# Altium schematic sheet Power and Ignition and Primary Processor.SchDoc
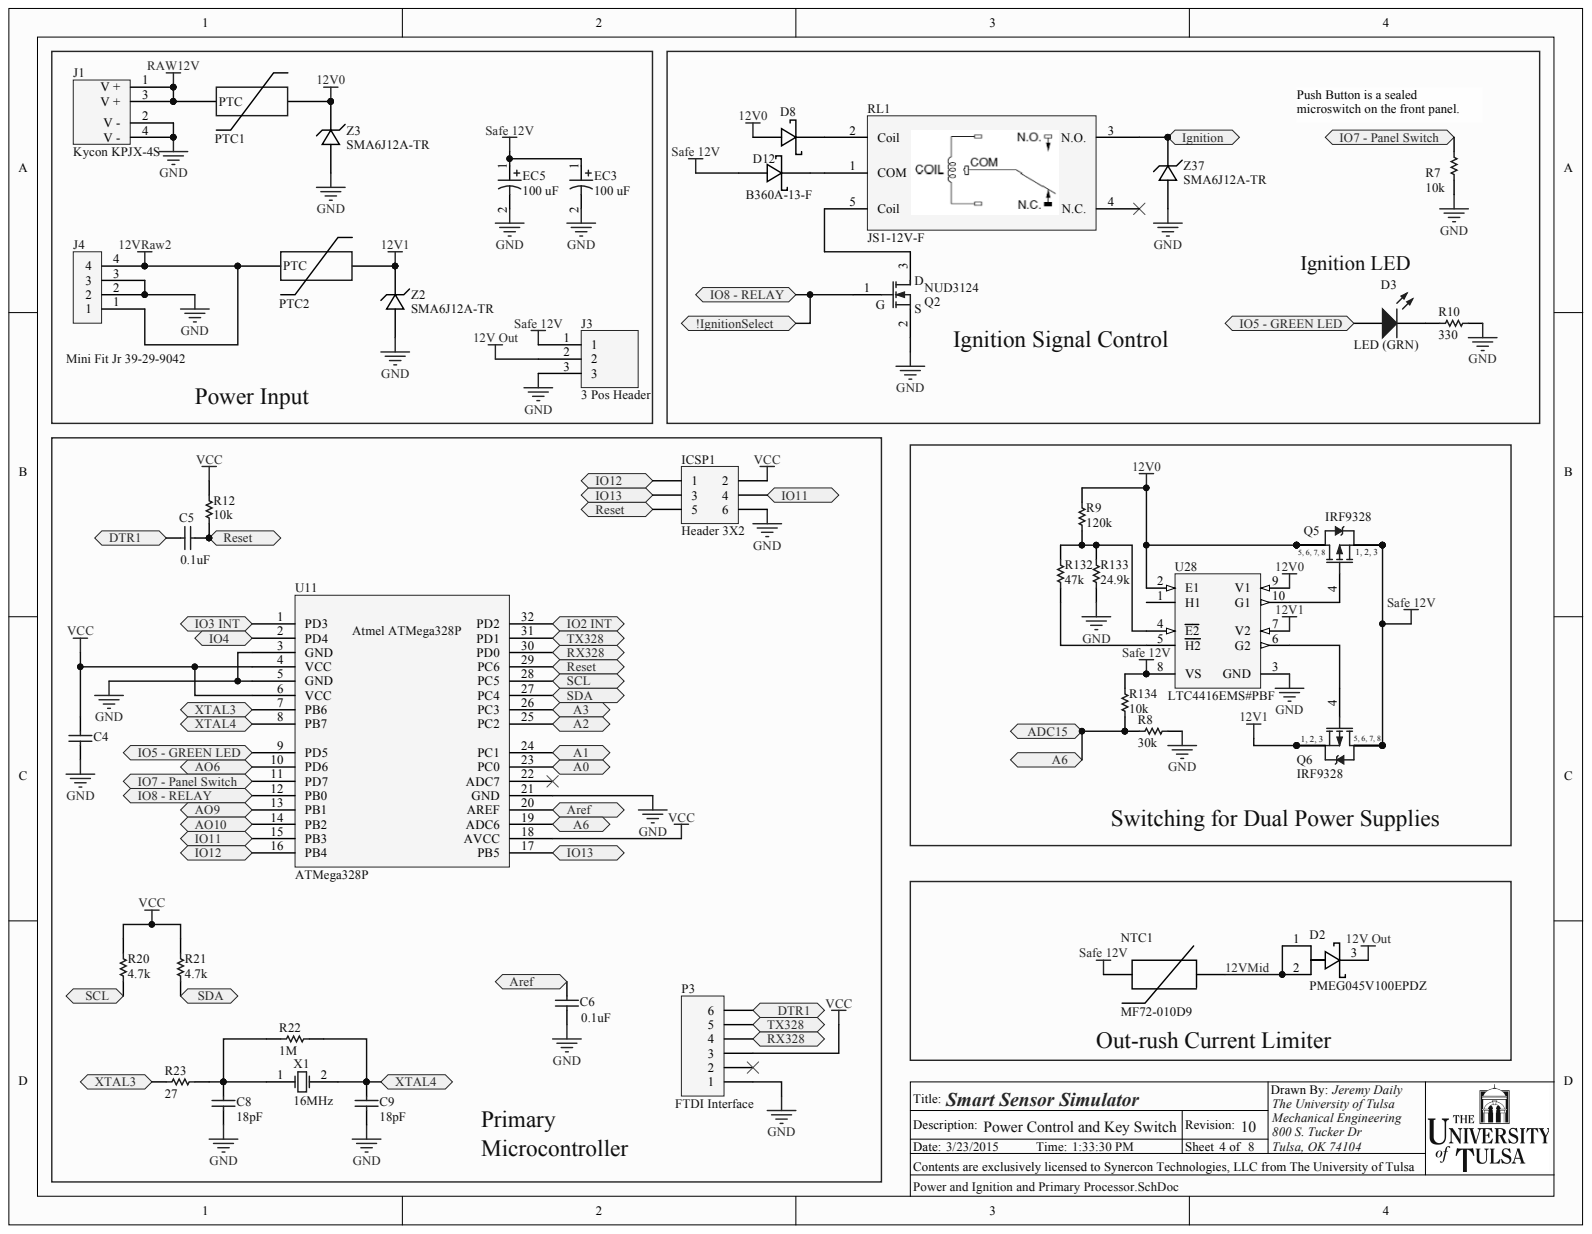
[diagram p0: Power and Ignition and Primary Processor.SchDoc]

## Parts
C4  0.1uF  [0603]  (value not drawn)
C5  0.1uF  [0603]
C6  0.1uF  [0603]
C8  18pF  [0603]
C9  18pF  [0603]
D2  PMEG045V100EPDZ  [SOT1289]
D3  LED (GRN)  [1206 Polarized]
D8  B360A-13-F  [SMA with A and K]
D12  B360A-13-F  [SMA with A and K]
EC3  100 uF  [Elec. Cap - D]
EC5  100 uF  [Elec. Cap - D]
ICSP1  Header 3X2  [ICSP Header (2x3)]
J1  Kycon KPJX-4S  Manufacturer: Kycon  [KPJX-4S-S]
J3  3 Pos Header  [3 POS MINI-FIT JR]
J4  Mini Fit Jr 39-29-9042  [4 POS Vertical MINI-FIT JR]
NTC1  MF72-010D9  [NTC 7.5mm]
P3  FTDI Interface  [FTDI Header]
PTC1  TE PTC  [PTC]  (value not drawn)
PTC2  TE PTC  [PTC]  (value not drawn)
Q2  NUD3124  [SOT-23-3]
Q5  IRF9328  [SO-8_L]
Q6  IRF9328  [SO-8_L]
R7  10k  [0805]
R8  30k  [0805]
R9  120k  [0805]
R10  330  [0603]
R12  10k  [0805]
R20  4.7k  [0805]
R21  4.7k  [0805]
R22  1M  [0603]
R23  27  [0805]
R132  47k  [0603]
R133  24.9k  [0805]
R134  10k  [0805]
RL1  JS1-12V-F  [Relay-JS]
U11  ATMega328P  Manufacturer: Atmel  [32MA_M]
U28  LTC4416EMS#PBF  [LT-MS-10_M]
X1  16MHz  [XTAL 2 pad]
Z2  SMA6J12A-TR  [SMA with A and K]
Z3  SMA6J12A-TR  [SMA with A and K]
Z37  SMA6J12A-TR  [SMA with A and K]

## Ports
!IGNITIONSELECT, A0, A1, A2, A3, A6, ADC15, AO6, AO9, AO10, AREF, DTR1, IGNITION, IO2 INT, IO3 INT, IO4, IO5 - GREEN LED, IO7 - PANEL SWITCH, IO8 - RELAY, IO11, IO12, IO13, RESET, RX328, SCL, SDA, TX328, XTAL3, XTAL4

## Nets
12V0: D8.1 PTC1.2 Q5.5 Q5.6 Q5.7 Q5.8 R9.1 U28.2(E1) U28.9(V1) Z3.2
12V1: PTC2.2 Q6.1 Q6.2 Q6.3 U28.7(V2) Z2.2
12V OUT: D2.3 J3.2
12VRAW2: J4.1 J4.4 PTC2.1
GND: C4.1 C6.2 C8.1 C9.1 EC3.2 EC5.2 ICSP1.6 J1.2(V_-) J1.4(V_-) J3.3 J4.2 J4.3 P3.1 Q2.2 R7.2 R8.2 R10.2 R133.2 U11.3(GND) U11.5(GND) U11.21(GND) U28.3(GND) Z2.1 Z3.1 Z37.1
RAW12V: J1.1(V_+) J1.3(V_+) PTC1.1
SAFE 12V: D12.1 EC3.1 EC5.1 J3.1 NTC1.1 Q5.1 Q5.2 Q5.3 Q6.5 Q6.6 Q6.7 Q6.8 R134.1 U28.8(VS)
VCC: C4.2 ICSP1.2 P3.3 R12.1 R20.2 R21.2 U11.4(VCC) U11.6(VCC) U11.18(AVCC)
12VMID: D2.1 D2.2 NTC1.2
A0: U11.23(PC0) ; port A0
A1: U11.24(PC1) ; port A1
A2: U11.25(PC2) ; port A2
A3: U11.26(PC3) ; port A3
A6: R8.1 R134.2 U11.19(ADC6) ; port A6, port ADC15
AO6: U11.10(PD6) ; port AO6
AO9: U11.13(PB1) ; port AO9
AO10: U11.14(PB2) ; port AO10
DTR1: C5.2 P3.6 ; port DTR1
IO2 INT: U11.32(PD2) ; port IO2 INT
IO3 INT: U11.1(PD3) ; port IO3 INT
IO4: U11.2(PD4) ; port IO4
IO5 - GREEN LED: D3.1 U11.9(PD5) ; port IO5 - GREEN LED
IO8 - RELAY: Q2.1 U11.12(PB0) ; port IO8 - RELAY
IO11: ICSP1.4 U11.15(PB3) ; port IO11
IO12: ICSP1.1 U11.16(PB4) ; port IO12
IO13: ICSP1.3 U11.17(PB5) ; port IO13
RX328: P3.4 U11.30(PD0) ; port RX328
SCL: R20.1 U11.28(PC5) ; port SCL
SDA: R21.1 U11.27(PC4) ; port SDA
TX328: P3.5 U11.31(PD1) ; port TX328
XTAL3: R23.2 U11.7(PB6) ; port XTAL3
XTAL4: C9.2 R22.1 U11.8(PB7) X1.2 ; port XTAL4
NetC5_1: C5.1 ICSP1.5 R12.2 U11.29(PC6) ; port RESET
NetC6_1: C6.1 U11.20(AREF) ; port AREF
NetC8_2: C8.2 R22.2 R23.1 X1.1
NetD3_2: D3.2 R10.1
NetD8_2: D8.2 RL1.2(Coil)
NetD12_2: D12.2 RL1.1(COM)
NetQ2_3: Q2.3 RL1.5(Coil)
NetQ5_4: Q5.4 U28.10(G1)
NetQ6_4: Q6.4 U28.6(G2)
NetR7_1: R7.1 U11.11(PD7) ; port IO7 - PANEL SWITCH
NetR9_2: R9.2 R132.1 R133.1 U28.4(E2)
NetR132_2: R132.2 U28.5(H2)
NetRL1_3: RL1.3(N.O.) Z37.2 ; port IGNITION

Not connected: P3 2; RL1 4(N.C.); U11 22(ADC7); U28 1(H1)

## Library data of the parts
C4-C6 = Cap: CAP CER 0.1UF 50V 10% X7R 0603; Manufacturer: Murata Electronics North America; PartNumber: GRM188R71H104KA93D; Component Kind: Standard; Library Name: SSS Schematic Library.SchLib; Signal Integrity: Cap; Simulation: CAP; Supplier 1: Digi-Key; Supplier Part Number 1: 490-1519-1-ND
C8 C9 = Cap: CAP CER 18PF 50V 5% NP0 0603; Manufacturer: Kemet; PartNumber: C0603C180J5GACTU; Component Kind: Standard; Library Name: SSS Schematic Library.SchLib; Signal Integrity: Cap; Simulation: CAP; Supplier 1: Digi-Key; Supplier Part Number 1: 399-1052-1-ND
D2 = Schottky Diode 3pin: DIODE SCHOTTKY 45V 10A CFP15; Manufacturer: NXP Semiconductors; Component Kind: Standard; Library Name: SSS Schematic Library.SchLib; PartNumber: PMEG045V100EPDZ; Supplier 1: Digi-Key; Supplier Part Number 1: 568-11697-1-ND
D3 = SMT LED: LED 3.2X1.6 525NM GN WTR CLR SMD; Manufacturer: Kingbright; PartNumber: APTD3216ZGC; Component Kind: Standard; Library Name: SSS Schematic Library.SchLib; Supplier 1: Digi-Key; Supplier Part Number 1: 754-1444-1-ND
D8 D12 = Schottky Diode: DIODE SCHOTTKY 60V 3A SMA; Manufacturer: Diodes Incorporated; Component Kind: Standard; Library Name: SSS Schematic Library.SchLib; PartNumber: B360A-13-F; Supplier 1: Digi-Key; Supplier Part Number 1: B360A-FDICT-ND
EC3 EC5 = SMD Elec: CAP ALUM 100UF 16V 20% SMD; Manufacturer: Panasonic; PartNumber: EEE-HC1C101XP; Component Kind: Standard; Library Name: SSS Schematic Library.SchLib; Supplier 1: Digi-Key; Supplier Part Number 1: PCE3750CT-ND
ICSP1 = Header 3X2: CONN HEADER 6POS DUAL .100 TIN; Manufacturer: 3M; PartNumber: 929836-01-03-RK; Component Kind: Standard; Library Name: Miscellaneous Connectors.IntLib; Signal Integrity: Connector; Supplier 1: Digi-Key; Supplier Part Number 1: 929836E-01-03-ND
J1 = Power Entry Jack - 4 Pin: DC Power Connectors 4P JACK SKT SHIELDED SNAP AND LOCK; Component Kind: Standard; Library Name: SSS Schematic Library.SchLib; PartNumber: KPJX-4S-S; Supplier 1: Mouser; Supplier Part Number 1: 806-KPJX-4S-S
J3 = 3 Pos Header: CONN HEADER 3POS 4.2MM VERT TIN; Manufacturer: Molex; Component Kind: Standard; Library Name: Mini Fit Jr.SchLib; PartNumber: 39-30-2030; Supplier 1: Digi-Key; Supplier Part Number 1: WM24035-ND
J4 = 4 Pos Header: CONN HEADER 4POS 4.2MM VERT TIN; Manufacturer: Molex; Component Kind: Standard; Library Name: Mini Fit Jr.SchLib; PartNumber: 39-29-9042; Supplier 1: Digi-Key; Supplier Part Number 1: WM7325-ND
NTC1 = NTC: CURRENT LIMITER INRUSH 10OHM 20%; Manufacturer: Cantherm; Component Kind: Standard; Library Name: SSS Schematic Library.SchLib; PartNumber: MF72-010D9; Supplier 1: Digi-Key; Supplier Part Number 1: 317-1161-ND
P3 = Header 6: Header, 6-Pin; Component Kind: Standard; Library Name: Miscellaneous Connectors.IntLib; Signal Integrity: Connector
PTC1 PTC2 = TE PTC: Resettable Fuses - PPTC AGRF600; Manufacturer: TE Connectivity / Raychem; Component Kind: Standard; Library Name: SSS Schematic Library.SchLib; PartNumber: AGRF600; Supplier 1: Mouser; Supplier Part Number 1: 650-AGRF600
Q2 = IRLML6344TRPbF: IC INDCT LOAD DRVR AUTO SOT23; Manufacturer: ON Semiconductor; PartNumber: NUD3124LT1G; Component Kind: Standard; Library Name: SSS Schematic Library.SchLib; Supplier 1: Digi-Key; Supplier Part Number 1: NUD3124LT1GOSCT-ND
Q5 Q6 = IRF9328 P-FET: MOSFET P-CH 30V 12A 8-SOIC; Manufacturer: International Rectifier; PartNumber: IRF9328PBF; Component Kind: Standard; Library Name: SSS Schematic Library.SchLib; Supplier 1: Digi-Key; Supplier Part Number 1: IRF9328PBF-ND
R7 R12 R133 R134 = Chip Resistor: RES SMD 10K OHM 1% 1/8W 0805; Manufacturer: Panasonic Electronic Components; PartNumber: ERJ-6ENF1002V; Component Kind: Standard; Library Name: SSS Schematic Library.SchLib; Supplier 1: Digi-Key; Supplier Part Number 1: P10.0KCCT-ND
R8 = Chip Resistor: RES SMD 30K OHM 5% 1/8W 0805; Manufacturer: Samsung Electro-Mechanics America, Inc; PartNumber: RC2012J303CS; Component Kind: Standard; Library Name: SSS Schematic Library.SchLib; Supplier 1: Digi-Key; Supplier Part Number 1: 1276-5563-1-ND
R9 = Chip Resistor: RES SMD 120K OHM 5% 1/10W 0603; Manufacturer: Vishay Dale; PartNumber: CRCW0603120KJNEA; Component Kind: Standard; Library Name: SSS Schematic Library.SchLib; Supplier 1: Digi-Key; Supplier Part Number 1: 541-120KGCT-ND
R10 = Chip Resistor: RES SMD 330 OHM 1% 1/10W 0603; Manufacturer: Panasonic Electronic Components; PartNumber: ERJ-3EKF3300V; Component Kind: Standard; Library Name: SSS Schematic Library.SchLib; Supplier 1: Digi-Key; Supplier Part Number 1: P330HCT-ND
R20 R21 = Chip Resistor: RES SMD 4.7K OHM 1% 1/8W 0805; Manufacturer: Panasonic Electronic Components; PartNumber: ERJ-6ENF4701V; Component Kind: Standard; Library Name: SSS Schematic Library.SchLib; Supplier 1: Digi-Key; Supplier Part Number 1: P4.70KCCT-ND
R22 = Chip Resistor: RES SMD 1M OHM 1% 1/10W 0603; Manufacturer: Panasonic Electronic Components; PartNumber: ERJ-3EKF1004V; Component Kind: Standard; Library Name: SSS Schematic Library.SchLib; Supplier 1: Digi-Key; Supplier Part Number 1: P1.00MHCT-ND
R23 = Chip Resistor: RES SMD 27 OHM 1% 1/8W 0805; Manufacturer: Panasonic Electronic Components; PartNumber: ERJ-6ENF27R0V; Component Kind: Standard; Library Name: SSS Schematic Library.SchLib; Supplier 1: Digi-Key; Supplier Part Number 1: P27.0CCT-ND
R132 = Chip Resistor: RES SMD 47K OHM 5% 1/10W 0603; Manufacturer: Vishay Dale; PartNumber: CRCW060347K0JNEA; Component Kind: Standard; Library Name: SSS Schematic Library.SchLib; Supplier 1: Digi-Key; Supplier Part Number 1: 541-47KGCT-ND
RL1 = Relay-JS: Panasonic JS General Purpose Power Relay; Manufacturer: Panasonic Electric Works; Component Kind: Standard; Library Name: SSS Schematic Library.SchLib; PartNumber: JS1-12V-F; Supplier 1: Digi-Key; Supplier Part Number 1: 255-2080-ND
U11 = ATMega328P: IC MCU 8BIT 32KB FLASH 32TQFP; PartNumber: ATMEGA328P-AU; Component Kind: Standard; Library Name: SSS Schematic Library.SchLib; Supplier 1: Digi-Key; Supplier Part Number 1: ATMEGA328P-AU-ND
U28 = LTC4416EMS#PBF: Low Loss Dual PowerPath Controller for Large PFET, 3.6 to 36 V Vin, 10-pin SOP (MS-10), -40 to 85 degC, Pb-Free; Manufacturer: Linear Technology; Ideal Diode: Dual, External, P-Channel; Component Kind: Standard; ComponentLink1Description: Manufacturer URL; ComponentLink2URL: http://cds.linear.com/docs/en/datasheet/4416fa.pdf; ComponentLink3Description: Package Specification; ComponentLink3URL: http://cds.linear.com/docs/en/packaging/05081661_F_MS.pdf; DatasheetVersion: Rev. A; Library Name: Linear Technology Power Management Power Control.IntLib; Mounting Technology: Surface Mount; PackageDescription: 10-Pin Plastic MSOP, Body 3 x 3 mm, Pitch 0.5 mm; PackageVersion: Rev. E, 06/2010; PartNumber: LTC4416EMS#PBF; RoHS: TRUE; Supplier 1: Digi-Key; Supplier 2: Newark; Supplier Part Number 1: LTC4416EMS#PBF-ND; Supplier Part Number 2: 56M9542
X1 = Crystal Oscillator: CRYSTAL 16MHZ 18PF SMD; Manufacturer: TXC CORPORATION; PartNumber: 7A-16.000MAAJ-T; Component Kind: Standard; Library Name: SSS Schematic Library.SchLib; Supplier 1: Digi-Key; Supplier Part Number 1: 887-1086-1-ND
Z2 Z3 Z37 = Zener: TVS DIODE 13VWM 21.5VC SMA; Manufacturer: Micro Commercial Co; PartNumber: SMA6J13CA-TP; Component Kind: Standard; Library Name: SSS Schematic Library.SchLib; Supplier 1: Digi-Key; Supplier Part Number 1: SMA6J13CA-TPMSCT-ND
